# Altium schematic sheet External_Board_1mode.SchDoc
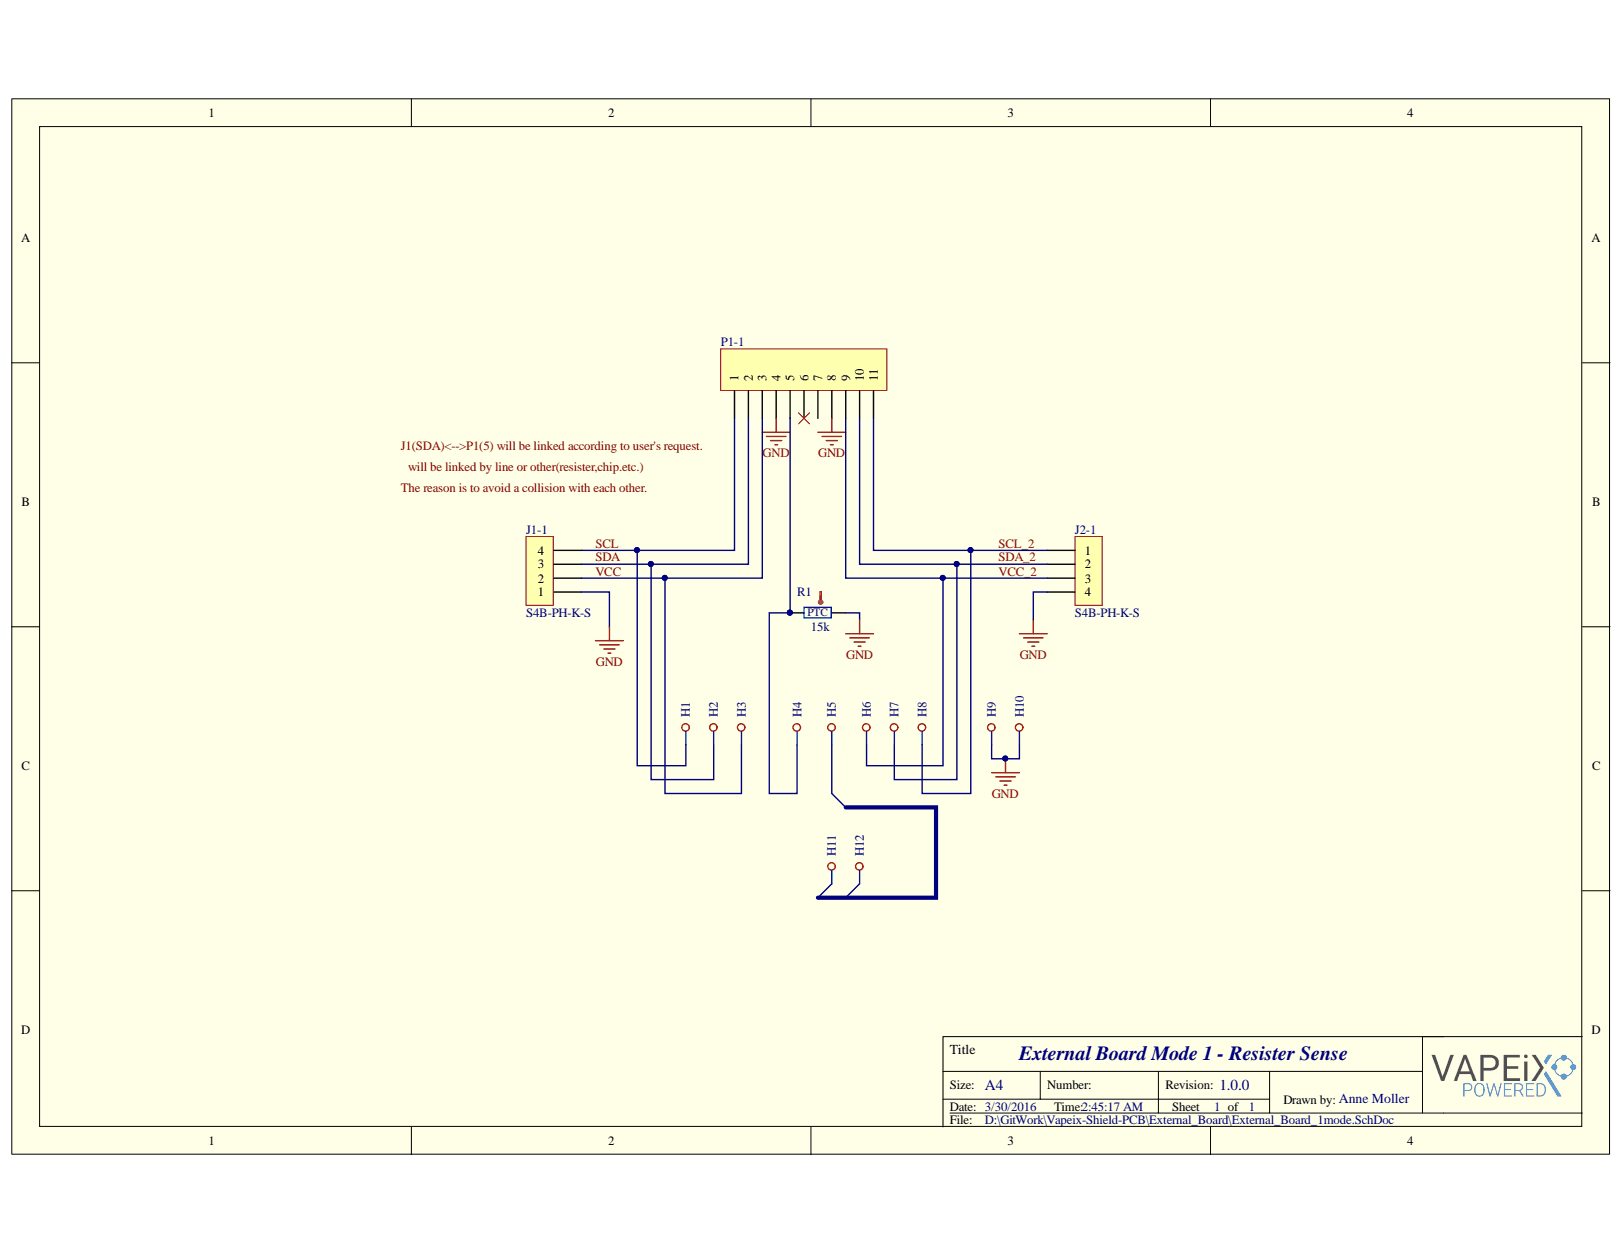
[diagram p0: External_Board_1mode.SchDoc]

## Parts
H1  TP_HOLE  [TestHole]  (value not drawn)
H2  TP_HOLE  [TestHole]  (value not drawn)
H3  TP_HOLE  [TestHole]  (value not drawn)
H4  TP_HOLE  [TestHole]  (value not drawn)
H5  TP_HOLE  [TestHole]  (value not drawn)
H6  TP_HOLE  [TestHole]  (value not drawn)
H7  TP_HOLE  [TestHole]  (value not drawn)
H8  TP_HOLE  [TestHole]  (value not drawn)
H9  TP_HOLE  [TestHole]  (value not drawn)
H10  TP_HOLE  [TestHole]  (value not drawn)
H11  TP_HOLE  [TestHole]  (value not drawn)
H12  TP_HOLE  [TestHole]  (value not drawn)
J1-1  S4B-PH-K-S  [CON4]
J2-1  S4B-PH-K-S  [CON4]
P1-1  Header11  [CON11]  (value not drawn)
R1  Resistance in Ohms @ 25°C: 15k  [PTFT1206L1501JV]  (hidden comment: PTFT1206L1501JV)

## Nets
GND: H9.1 H10.1 J1-1.1 J2-1.4 P1-1.4 P1-1.8 R1.1
SCL: H1.1 J1-1.4 P1-1.1
SCL_2: H8.1 J2-1.1 P1-1.11
SDA: H2.1 J1-1.3 P1-1.2
SDA_2: H7.1 J2-1.2 P1-1.10
VCC: H3.1 J1-1.2 P1-1.3
VCC_2: H6.1 J2-1.3 P1-1.9
NetH4_1: H4.1 P1-1.5 R1.2
J1(SDA)<-->P1(5) WILL BE LINKED ACCORDING TO USER'S REQUEST.:
N00003:
THE REASON IS TO AVOID A COLLISION WITH EACH OTHER.:
WILL BE LINKED BY LINE OR OTHER(RESISTER,CHIP.ETC.):

Not connected: H5 1; H11 1; H12 1; P1-1 6 7

## Library data of the parts
H1-H12 = TP_HOLE: TEST POINT HOLE; Component Kind: Standard; Library Name: ExternalLib.SchLib
J1-1 J2-1 = S4B-PH-K-S (LF)(SN): JST (JAPAN SOLDERLESS TERMINALS) - S4B-PH-K-S (LF)(SN) - CONNECTOR, HEADER, 2MM, 4 POSITION, 1ROW; Component Kind: Standard; ComponentLink1Description: http://www.farnell.com/datasheets/80564.pdf; ComponentLink1URL: http://www.farnell.com/datasheets/80564.pdf; Manufacturer: JST (JAPAN SOLDERLESS TERMINALS); Manufacturer Part Number: S4B-PH-K-S (LF)(SN); Pricing 1: 1=0.14, 250=0.126, 500=0.112, 1000=0.101, 5000=0.069 (USD); RoHS: YES; Stock 1: 908; Supplier 1: Newark; Library Name: ExternalLib.SchLib
P1-1 = SFH11-PBPC-D10-ST-BK: CONN HEADR FMALE 20POS .1" DL AU; Manufacturer: Sullins Connector Solutions; Connector Type: Header; Number of Positions: 20; Number of Positions Loaded: All; Pitch: 0.100" (2.54mm); Category: Connectors, Interconnects; Color: Black; Component Kind: Standard; ComponentLink1Description: http://sullinscorp.com/catalogs/146_PAGE119_.100_SFH11_SERIES_FEMALE_HDR_ST_RA.pdf; ComponentLink1URL: http://sullinscorp.com/catalogs/146_PAGE119_.100_SFH11_SERIES_FEMALE_HDR_ST_RA.pdf; Contact Finish: Gold; Contact Finish Thickness: Flash; Contact Type: Female Socket; Fastening Type: -; Features: Polarizing Key; Manufacturer Part Number: SFH11-PBPC-D10-ST-BK; Mounting Type: Through Hole; Number of Rows: 2; Packaging: Tray; Pricing 1: 1=1.43, 10=1.185, 25=1.0976, 50=0.9878, 100=0.878, 250=0.76824, 500=0.7024, 1000=0.63655, 2500=0.5707 (USD); RoHS: RoHS Compliant; Row Spacing: 0.100" (2.54mm); Stock 1: 14457; Supplier 1: Digi-Key; Termination: Solder; Library Name: ExternalLib.SchLib
R1 = PTFT1206L1501JV: THERMISTOR PTC 1.5K OHM 5% 1206; Manufacturer: Vishay Dale; Power - Max: 125mW; Category: Sensors, Transducers; Component Kind: Standard; ComponentLink1Description: http://media.digikey.com/pdf/Data%20Sheets/Vishay%20Dale%20PDFs/PTFT.pdf; ComponentLink1URL: http://media.digikey.com/pdf/Data%20Sheets/Vishay%20Dale%20PDFs/PTFT.pdf; Manufacturer Part Number: PTFT1206L1501JV; Mounting Type: Surface Mount; Operating Temperature: -55°C ~ 125°C; Package / Case: 1206 (3216 Metric); Packaging: Tape & Reel (TR); Pricing 1: 1000=0 (USD); Resistance Tolerance: ±5%; RoHS: RoHS Compliant; Stock 1: 0; Supplier 1: Digi-Key; Supplier Device Package: 1206; Library Name: ExternalLib.SchLib
